# Altium schematic sheet FanBot.SchDoc
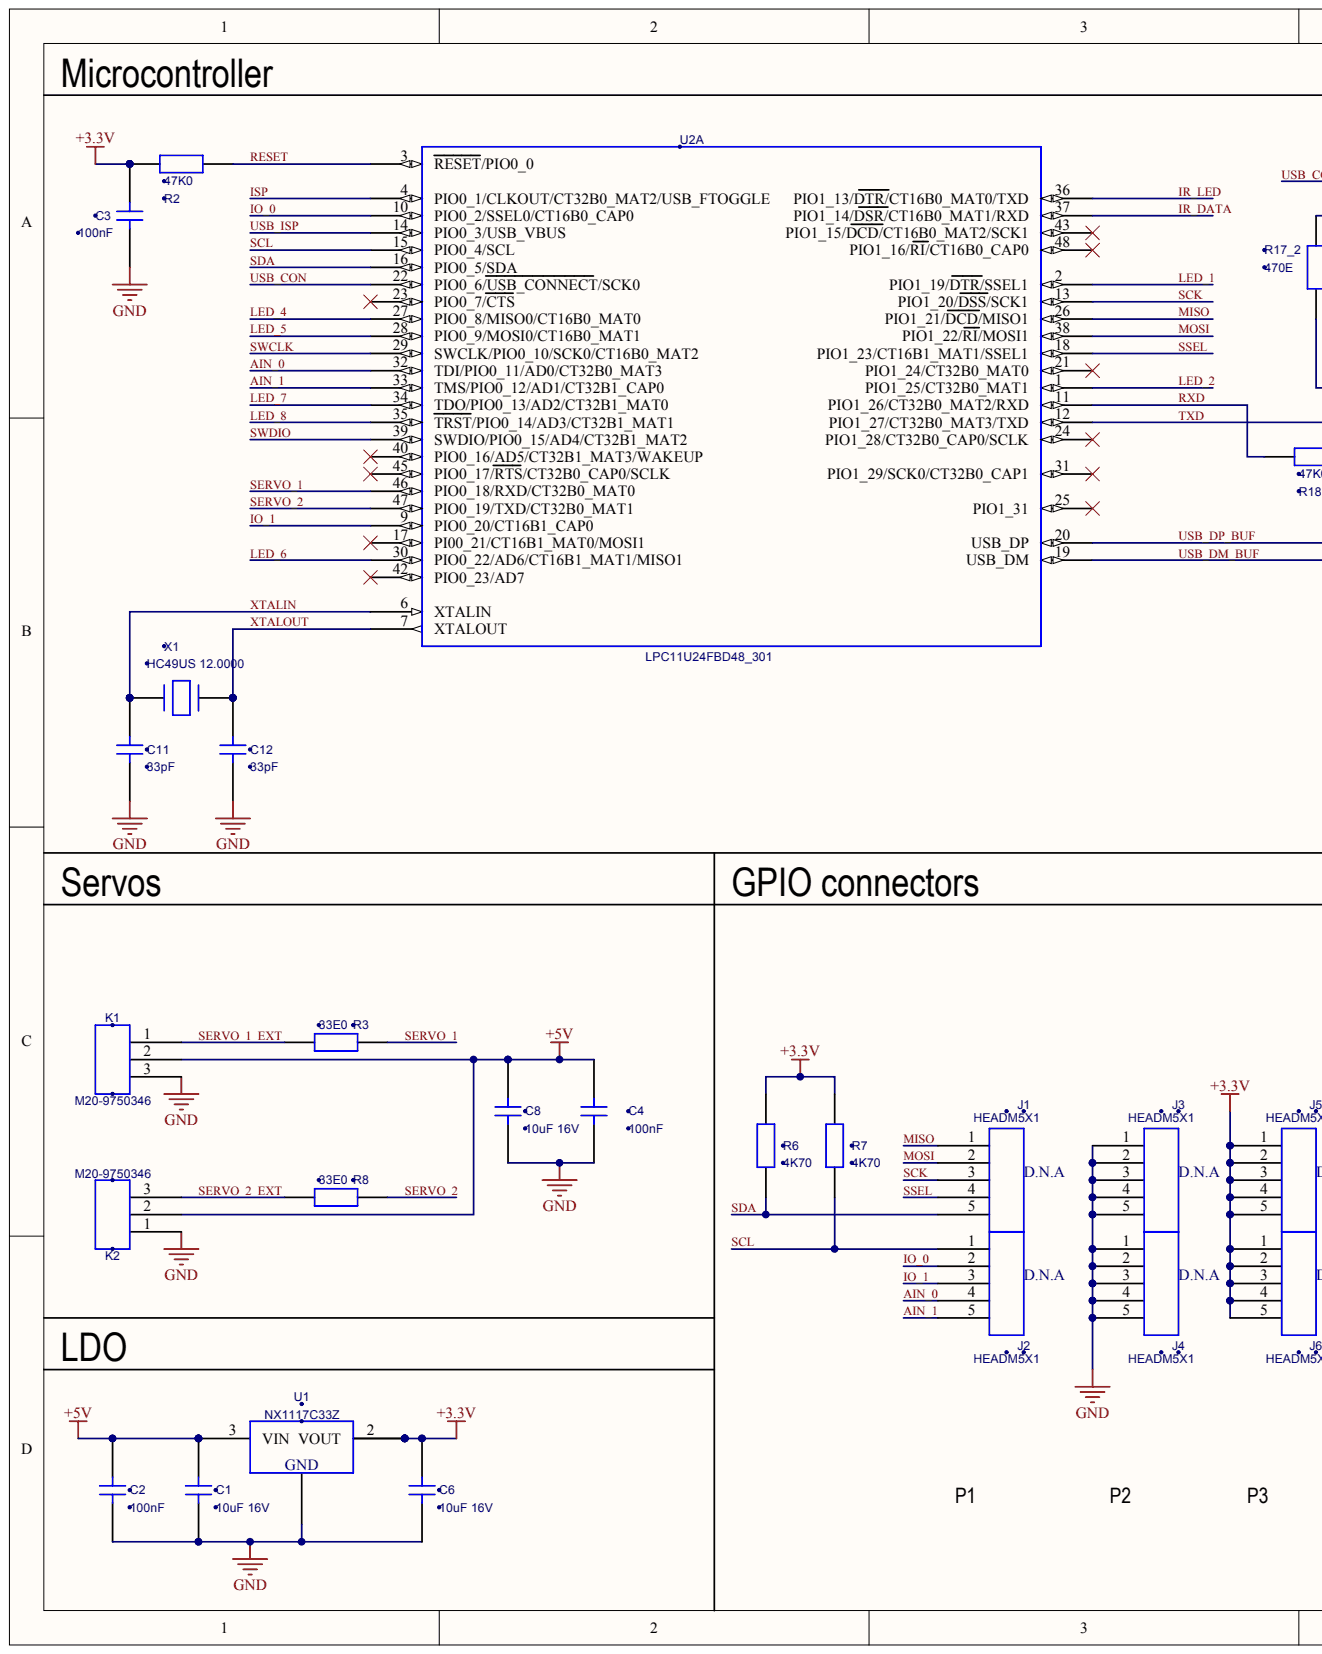
[diagram p0: FanBot.SchDoc, left]
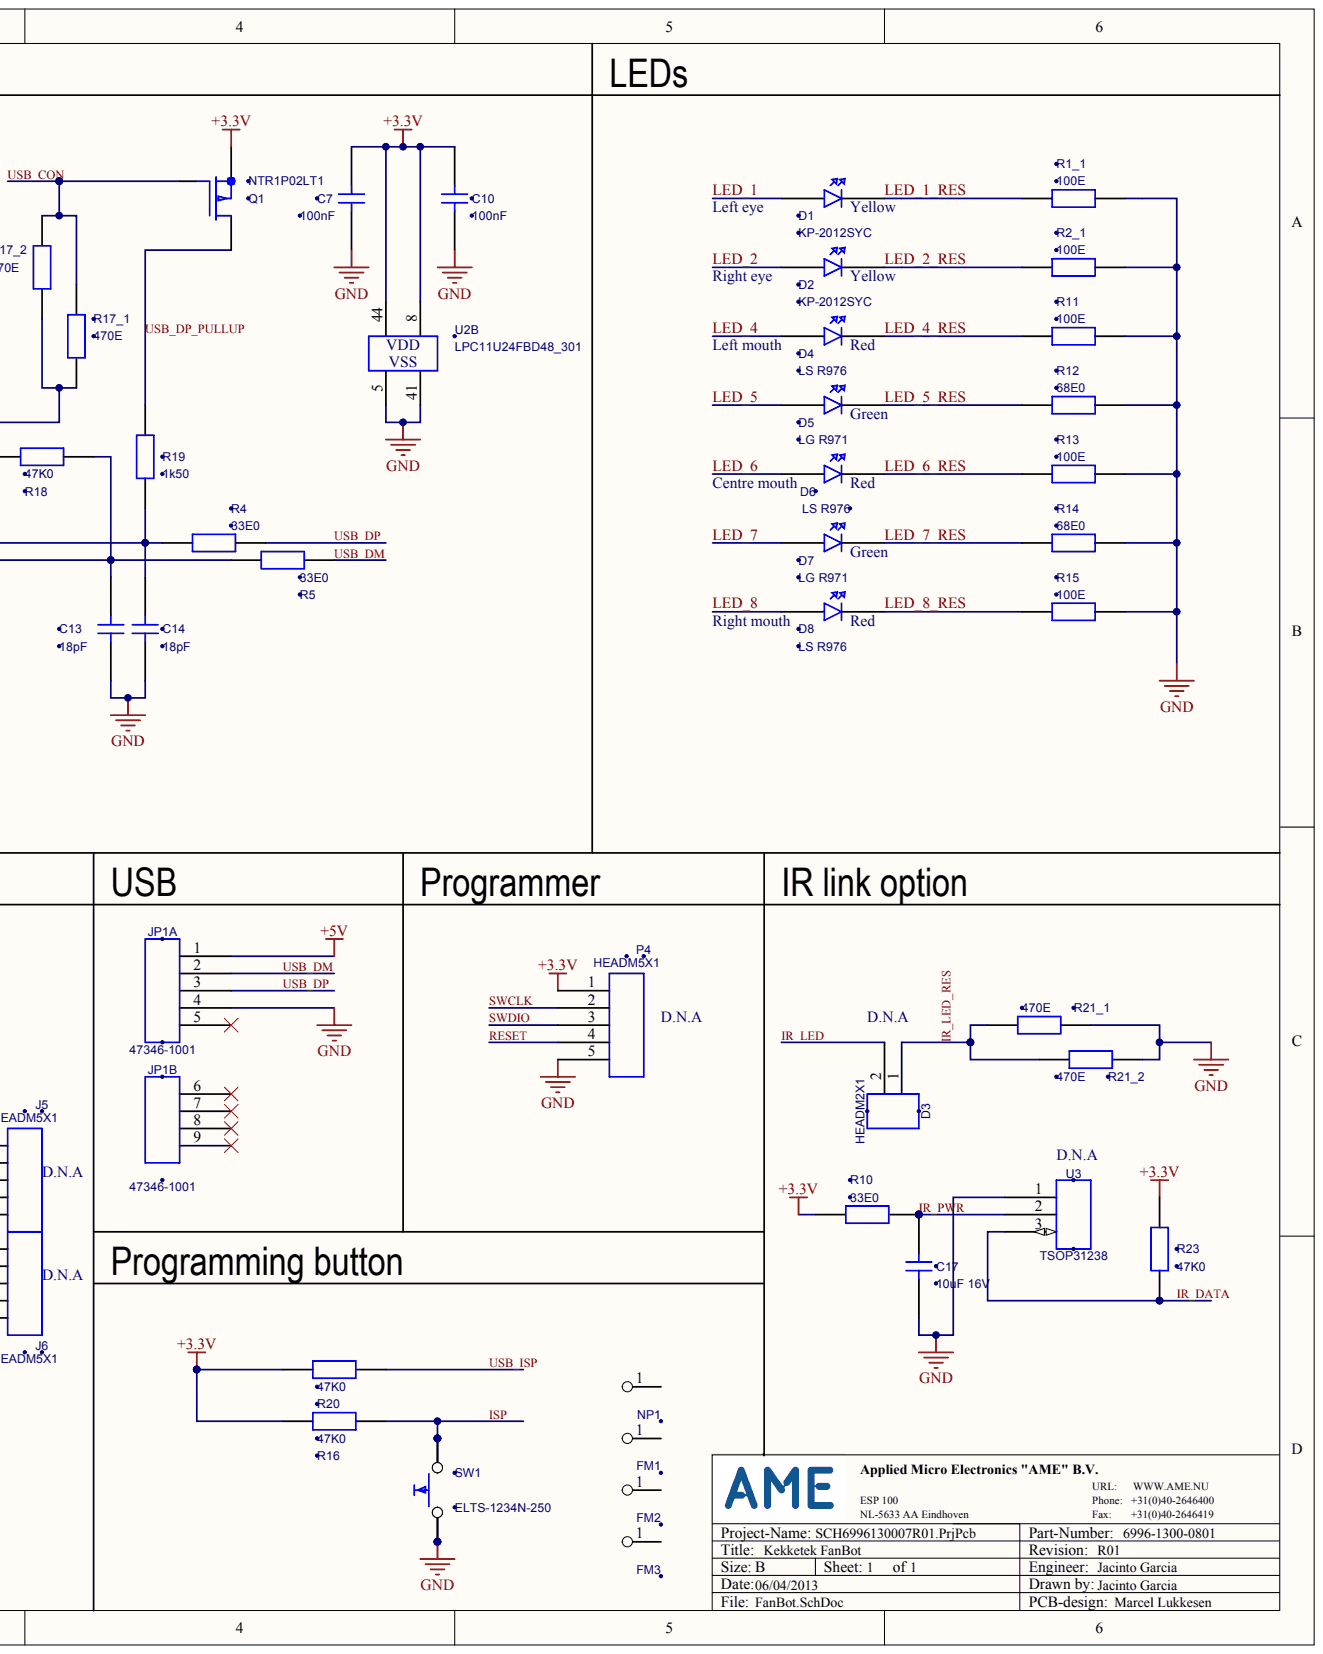
[diagram p1: FanBot.SchDoc, right]

## Parts
C1  10U 0805 X5R16V  (value not drawn)
C2  Pvalue: 100nF  (hidden comment: 100N 0805 X7R50V)
C3  Pvalue: 100nF  (hidden comment: 100N 0805 X7R50V)
C4  Pvalue: 100nF  (hidden comment: 100N 0805 X7R50V)
C6  Pvalue: 10uF 16V  (hidden comment: 10U 0805 X5R16V)
C7  Pvalue: 100nF  (hidden comment: 100N 0805 X7R50V)
C8  10U 0805 X5R16V  (value not drawn)
C10  Pvalue: 100nF  (hidden comment: 100N 0805 X7R50V)
C11  Pvalue: 33pF  (hidden comment: 33P 0805 X7R50V)
C12  Pvalue: 33pF  (hidden comment: 33P 0805 X7R50V)
C13  Pvalue: 18pF  (hidden comment: 18P 0805 X7R50V)
C14  Pvalue: 18pF  (hidden comment: 18P 0805 X7R50V)
C17  Pvalue: 10uF 16V  (hidden comment: 10U 0805 X5R16V)
D1  KP-2012SYC
D2  KP-2012SYC
D3  HEADM2X1
D4  LS R976
D5  LG R971
D6  LS R976
D7  LG R971
D8  LS R976
FM1  FIDUCIAL MARK 1  (value not drawn)
FM2  FIDUCIAL MARK 1  (value not drawn)
FM3  FIDUCIAL MARK 1  (value not drawn)
J1  HEADM5X1
J2  HEADM5X1
J3  HEADM5X1
J4  HEADM5X1
J5  HEADM5X1
J6  HEADM5X1
JP1  47346-1001  (parts A,B)
K1  M20-9750346
K2  M20-9750346
NP1  NULPUNT  (value not drawn)
P4  HEADM5X1
Q1  NTR1P02LT1
R1_1  Pvalue: 100E  (hidden comment: 100E 0805 1%)
R2  Pvalue: 47K0  (hidden comment: 47K0 0805 1%)
R2_1  Pvalue: 100E  (hidden comment: 100E 0805 1%)
R3  Pvalue: 33E0  (hidden comment: 33E0 0805 1%)
R4  Pvalue: 33E0  (hidden comment: 33E0 0805 1%)
R5  Pvalue: 33E0  (hidden comment: 33E0 0805 1%)
R6  Pvalue: 4K70  (hidden comment: 4K70 0805 1%)
R7  Pvalue: 4K70  (hidden comment: 4K70 0805 1%)
R8  Pvalue: 33E0  (hidden comment: 33E0 0805 1%)
R10  Pvalue: 33E0  (hidden comment: 33E0 0805 1%)
R11  Pvalue: 100E  (hidden comment: 100E 0805 1%)
R12  Pvalue: 68E0  (hidden comment: 68E0 0805 1%)
R13  Pvalue: 100E  (hidden comment: 100E 0805 1%)
R14  Pvalue: 68E0  (hidden comment: 68E0 0805 1%)
R15  Pvalue: 100E  (hidden comment: 100E 0805 1%)
R16  Pvalue: 47K0  (hidden comment: 47K0 0805 1%)
R17_1  Pvalue: 470E  (hidden comment: 470E 0805 1%)
R17_2  Pvalue: 470E  (hidden comment: 470E 0805 1%)
R18  Pvalue: 47K0  (hidden comment: 47K0 0805 1%)
R19  Pvalue: 1k50  (hidden comment: 1K50 0805 1%)
R20  Pvalue: 47K0  (hidden comment: 47K0 0805 1%)
R21_1  Pvalue: 470E  (hidden comment: 470E 0805 1%)
R21_2  Pvalue: 470E  (hidden comment: 470E 0805 1%)
R23  Pvalue: 47K0  (hidden comment: 47K0 0805 1%)
SW1  ELTS-1234N-250
U1  NX1117C33Z
U2  LPC11U24FBD48_301  (parts A,B)
U3  TSOP31238
X1  HC49US 12.0000

## Nets
+3.3V: C3.1 C6.1 C7.1 C10.1 J5.1 J5.2 J5.3 J5.4 J5.5 J6.1 J6.2 J6.3 J6.4 J6.5 P4.1 Q1.2 R2.2 R6.1 R7.1 R10.1 R16.2 R20.2 R23.1 U1.2(VOUT) U1.4 U2.8 U2.44
+5V: C1.1 C2.1 C4.1 C8.1 JP1.1 K1.2 K2.2 U1.3(VIN)
GND: C1.2 C2.2 C3.2 C4.2 C6.2 C7.2 C8.2 C10.2 C11.2 C12.2 C13.2 C14.2 C17.2 J3.1 J3.2 J3.3 J3.4 J3.5 J4.1 J4.2 J4.3 J4.4 J4.5 JP1.4 K1.3 K2.1 P4.5 R1_1.2 R2_1.2 R11.2 R12.2 R13.2 R14.2 R15.2 R21_1.2 R21_2.2 SW1.2 SW1.4 U1.1 U2.5 U2.41 U3.1
AIN_0: J2.4 U2.32(TDI/PIO0_11/AD0/CT32B0_MAT3)
AIN_1: J2.5 U2.33(TMS/PIO0_12/AD1/CT32B1_CAP0)
IO_0: J2.2 U2.10
IO_1: J2.3 U2.9
IR_DATA: R23.2 U2.37(PIO1_14/DSR/CT16B0_MAT1/RXD) U3.3
IR_LED: D3.2 U2.36(PIO1_13/DTR/CT16B0_MAT0/TXD)
IR_LED_RES: D3.1 R21_1.1 R21_2.1
IR_PWR: C17.1 R10.2 U3.2
ISP: R16.1 SW1.1 SW1.3 U2.4
LED_1: D1.2 U2.2(PIO1_19/DTR/SSEL1)
LED_1_RES: D1.1 R1_1.1
LED_2: D2.2 U2.1(PIO1_25/CT32B0_MAT1)
LED_2_RES: D2.1 R2_1.1
LED_4: D4.2 U2.27
LED_4_RES: D4.1 R11.1
LED_5: D5.2 U2.28
LED_5_RES: D5.1 R12.1
LED_6: D6.2 U2.30
LED_6_RES: D6.1 R13.1
LED_7: D7.2 U2.34(TDO/PIO0_13/AD2/CT32B1_MAT0)
LED_7_RES: D7.1 R14.1
LED_8: D8.2 U2.35(TRST/PIO0_14/AD3/CT32B1_MAT1)
LED_8_RES: D8.1 R15.1
MISO: J1.1 U2.26(PIO1_21/DCD/MISO1)
MOSI: J1.2 U2.38(PIO1_22/RI/MOSI1)
RESET: P4.4 R2.1 U2.3(RESET/PIO0_0)
RXD: R18.2 U2.11(PIO1_26/CT32B0_MAT2/RXD)
SCK: J1.3 U2.13(PIO1_20/DSS/SCK1)
SCL: J2.1 R7.2 U2.15
SDA: J1.5 R6.2 U2.16
SERVO_1: R3.2 U2.46
SERVO_1_EXT: K1.1 R3.1
SERVO_2: R8.2 U2.47
SERVO_2_EXT: K2.3 R8.1
SSEL: J1.4 U2.18(PIO1_23/CT16B1_MAT1/SSEL1)
SWCLK: P4.2 U2.29(SWCLK/PIO0_10/SCK0/CT16B0_MAT2)
SWDIO: P4.3 U2.39(SWDIO/PIO0_15/AD4/CT32B1_MAT2)
TXD: R17_1.2 R17_2.2 U2.12(PIO1_27/CT32B0_MAT3/TXD)
USB_CON: Q1.1 R17_1.1 R17_2.1 U2.22
USB_DM: JP1.2 R5.2
USB_DM_BUF: C13.1 R5.1 R18.1 U2.19(USB_DM)
USB_DP: JP1.3 R4.2
USB_DP_BUF: C14.1 R4.1 R19.2 U2.20(USB_DP)
USB_DP_PULLUP: Q1.3 R19.1
USB_ISP: R20.1 U2.14
XTALIN: C11.1 U2.6(XTALIN) X1.1
XTALOUT: C12.1 U2.7(XTALOUT) X1.2

Not connected: FM1 1; FM2 1; FM3 1; JP1 5 6 7 8 9; NP1 1; U2 17 21(PIO1_24/CT32B0_MAT0) 23 24(PIO1_28/CT32B0_CAP0/SCLK) 25(PIO1_31) 31(PIO1_29/SCK0/CT32B0_CAP1) 40 42 43(PIO1_15/DCD/CT16B0_MAT2/SCK1) 45 48(PIO1_16/RI/CT16B0_CAP0)

## Library data of the parts
C1 C8 = (no symbol name): Part Number: 120400051105; Manufacturer: AVX; OrderCode: 0805YD106KAT2A; Pvalue: 10uF 16V; ModifyDate: 4/17/2013
C2-C4 C7 C10 = (no symbol name): Part Number: 120400011003; Manufacturer: Kemet; OrderCode: C0805C104K5RACTU; Value: *
C6 C17 = (no symbol name): Part Number: 120400051105; Manufacturer: AVX; OrderCode: 0805YD106KAT2A; ModifyDate: 4/17/2013
C11 C12 = (no symbol name): Part Number: 120400013309; Manufacturer: Kemet; OrderCode: C0805C330K5RACTU; Value: *
C13 C14 = (no symbol name): Part Number: 120400011809; Manufacturer: Kemet; OrderCode: C0805C180K5RACTU; Value: *
D1 D2 = (no symbol name): Part Number: 206000010035; Manufacturer: Kingbright; Pvalue: YE; Ordercode: KP-2012SYC; ModifyDate: 10/01/2009 12:30:55; Value: *
D3 = (no symbol name): Part Number: 505000030003; Manufacturer: Harwin; OrderCode: M20-9730206 , 2-polig; ModifyDate: 11/30/2005 12:07:28; Value: *
D4 D6 D8 = (no symbol name): Part Number: 206000010024; Manufacturer: Osram; Ordercode: Q62702-P5178; Pvalue: *; ModifyDate: 01/13/2011 08:56:36; Value: *
D5 D7 = (no symbol name): Part Number: 206000010001; Manufacturer: Osram; Ordercode: LGR971-KL; Pvalue: *; ModifyDate: 01/13/2011 08:56:22; Value: *
J1-J6 P4 = (no symbol name): Part Number: 505000080023; Manufacturer: Harwin; OrderCode: M20-9770522; ModifyDate: 01/11/2012 15:11:41; Value: *
JP1 = (no symbol name): Part Number: 509000220067; Manufacturer: Molex; OrderCode: 47346-1001; ModifyDate: 10/05/2012 13:59:18; Value: *
K1 K2 = (no symbol name): Part Number: 505000080120; Manufacturer: Harwin; OrderCode: M20-9750346; ModifyDate: 4/29/2013
Q1 = (no symbol name): Part Number: 222000010018; Manufacturer: ON Semiconductor; Ordercode: NTR1P02LT1; ModifyDate: 11/23/2007 10:43:03; Value: *
R1_1 R2_1 R11 R13 R15 = (no symbol name): Part Number: 110200011000; Manufacturer: ASJ; OrderCode: CR211000FF; Value: *
R2 R16 R18 R20 R23 = (no symbol name): Part Number: 110200014702; Manufacturer: ASJ; OrderCode: CR214702FF; Value: *
R3-R5 R8 R10 = (no symbol name): Part Number: 110200013309; Manufacturer: Yageo; OrderCode: RC0805FR-0733RL; Value: *
R6 R7 = (no symbol name): Part Number: 110200014701; Manufacturer: ASJ; OrderCode: CR214701FF; Value: *
R12 R14 = (no symbol name): Part Number: 110200016809; Manufacturer: ASJ; OrderCode: CR216809FF; Value: *
R17_1 R17_2 R21_1 R21_2 = (no symbol name): Part Number: 110200014700; Manufacturer: ASJ; OrderCode: CR214700FF; Value: *
R19 = (no symbol name): Part Number: 110200011501; Manufacturer: ASJ; OrderCode: CR211501FL; Value: *
SW1 = (no symbol name): Part Number: 513000010022; Manufacturer: Eledis; Note: PastaOverprint for 125um; OrderCode: ELTS-1234N-250; ModifyDate: 3/25/2013
U1 = (no symbol name): Part Number: 330000010048; Manufacturer: NXP Semiconductor; Ordercode: NX1117C33Z,115; ModifyDate: 4/17/2013
U2 = (no symbol name): Part Number: 411000010007; Manufacturer: NXP Semiconductor; OrderCode: LPC11U24FBD48/301; ModifyDate: 4/9/2013
U3 = (no symbol name): Part Number: 324000010007; Manufacturer: Vishay; OrderCode: TSOP31238; ModifyDate: 04/23/2009 11:33:49; Value: *
X1 = (no symbol name): Part Number: 140000010015; Manufacturer: Hong Kong Crystals; PValue: 12.0000MHz; Ordercode: SSM1200000E15FAGZ80S; ModifyDate: 11/29/2010 08:12:29; Value: *
FM1-FM3 NP1 = (no symbol name): Value: *
